# Altium schematic sheet Power Supply.SchDoc("Power Supply")
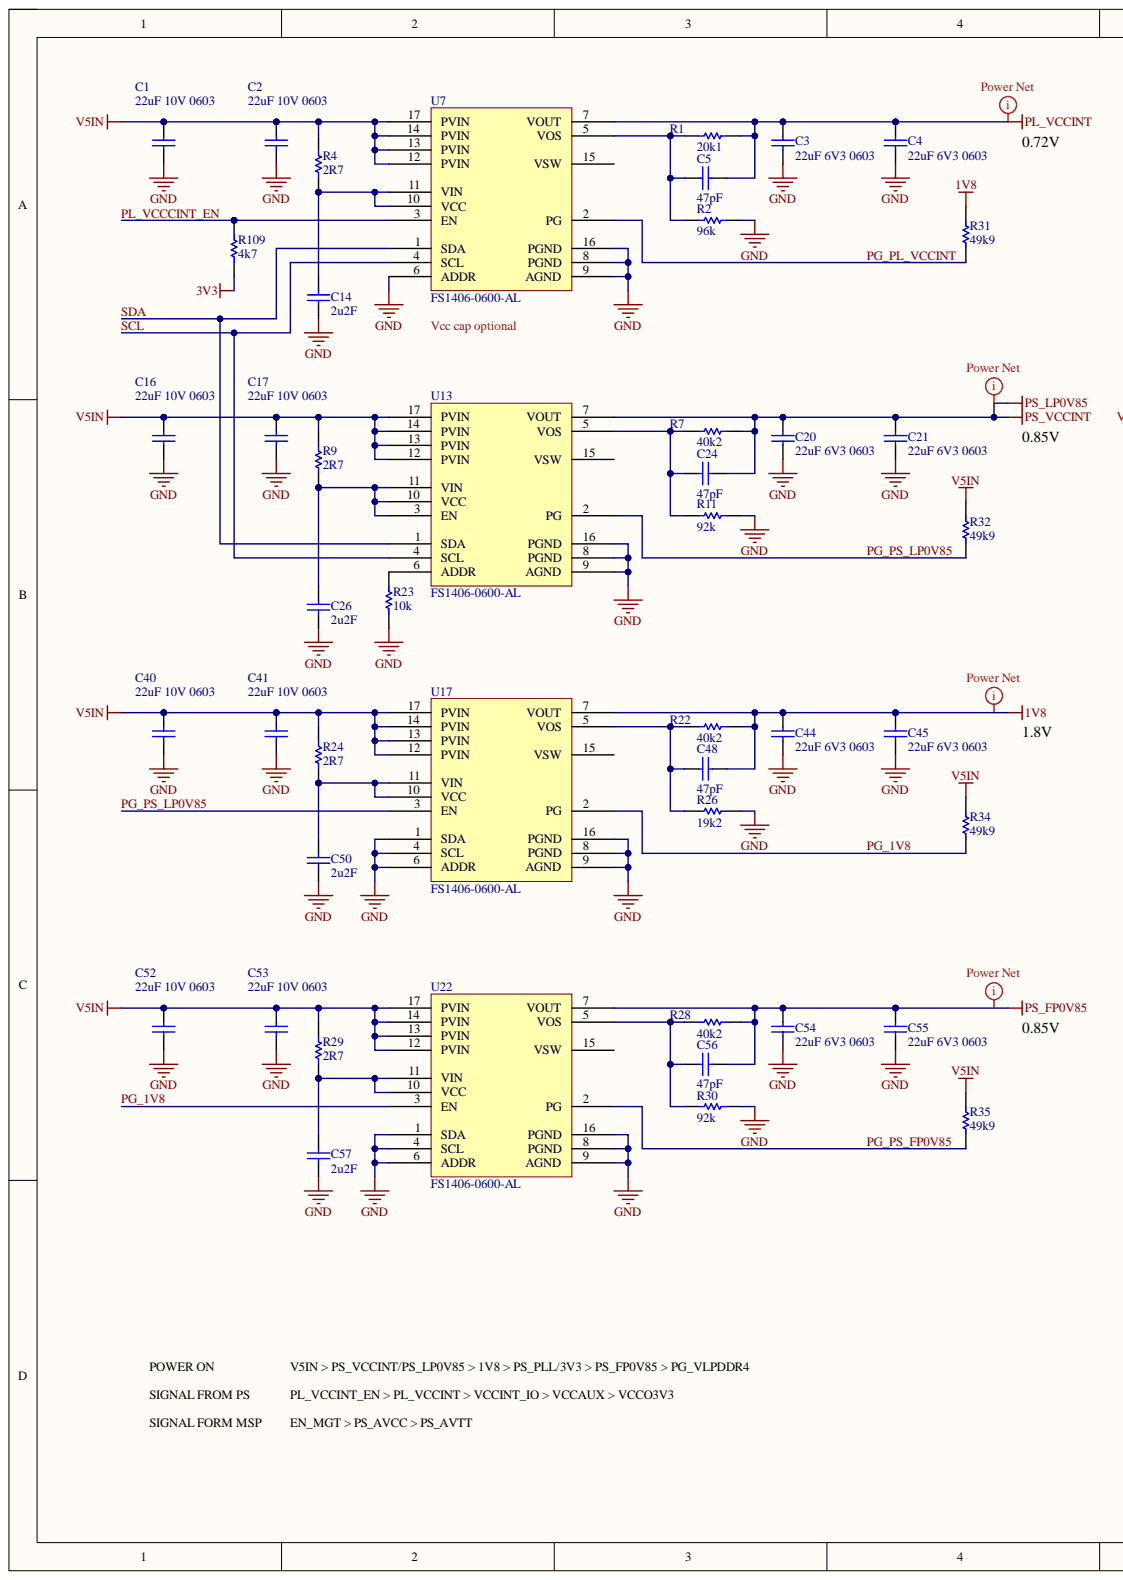
[diagram p0: Power Supply.SchDoc, left]
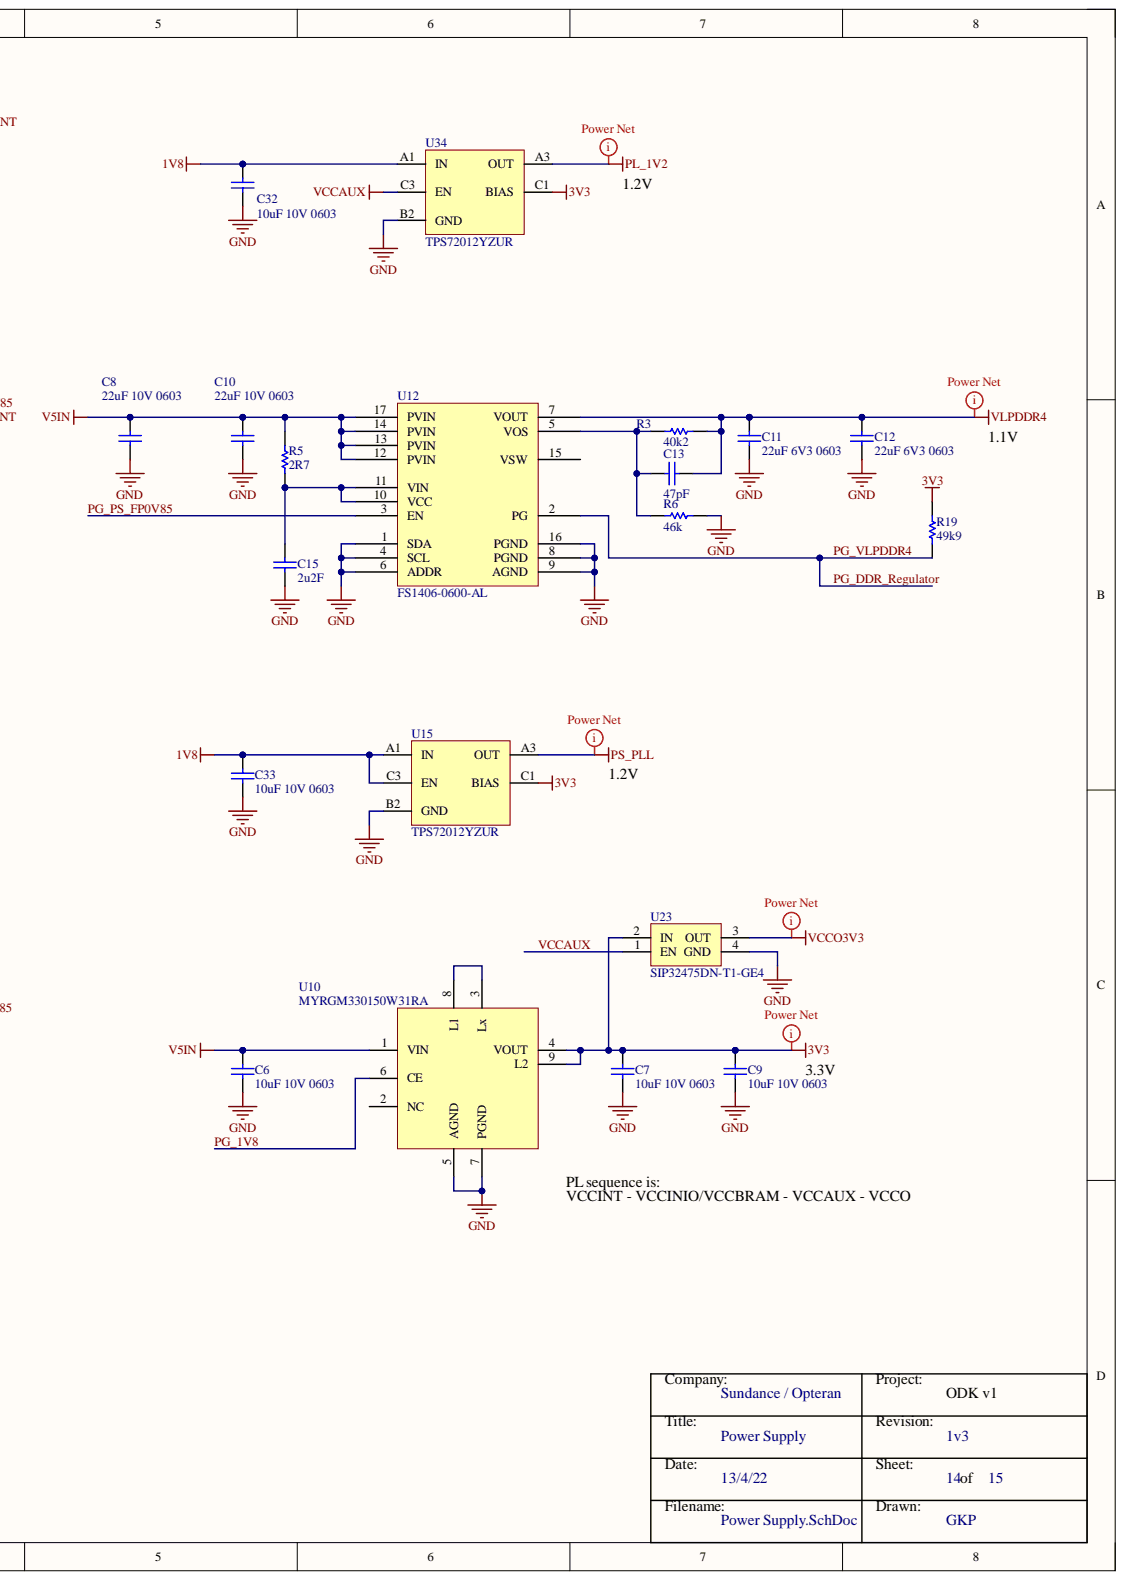
[diagram p1: Power Supply.SchDoc, right]

## Parts
C1  22uF 10V 0603  Case-EIA: 0603  [CAP0603]
C2  22uF 10V 0603  Case-EIA: 0603  [CAP0603]
C3  22uF 6V3 0603  [CAP0603]
C4  22uF 6V3 0603  Case-EIA: 0603  [CAP0603]
C5  47pF  [0201panERJ]
C6  10uF 10V 0603  [CAP0603]
C7  10uF 10V 0603  [CAP0603]
C8  22uF 10V 0603  Case-EIA: 0603  [CAP0603]
C9  10uF 10V 0603  Case-EIA: 0603  [CAP0603]
C10  22uF 10V 0603  Case-EIA: 0603  [CAP0603]
C11  22uF 6V3 0603  [CAP0603]
C12  22uF 6V3 0603  Case-EIA: 0603  [CAP0603]
C13  47pF  [0201panERJ]
C14  2u2F  [0201panERJ]
C15  2u2F  [0201panERJ]
C16  22uF 10V 0603  Case-EIA: 0603  [CAP0603]
C17  22uF 10V 0603  Case-EIA: 0603  [CAP0603]
C20  22uF 6V3 0603  [CAP0603]
C21  22uF 6V3 0603  Case-EIA: 0603  [CAP0603]
C24  47pF  [0201panERJ]
C26  2u2F  [0201panERJ]
C32  10uF 10V 0603  [CAP0603]
C33  10uF 10V 0603  [CAP0603]
C40  22uF 10V 0603  Case-EIA: 0603  [CAP0603]
C41  22uF 10V 0603  Case-EIA: 0603  [CAP0603]
C44  22uF 6V3 0603  [CAP0603]
C45  22uF 6V3 0603  Case-EIA: 0603  [CAP0603]
C48  47pF  [0201panERJ]
C50  2u2F  [0201panERJ]
C52  22uF 10V 0603  Case-EIA: 0603  [CAP0603]
C53  22uF 10V 0603  Case-EIA: 0603  [CAP0603]
C54  22uF 6V3 0603  [CAP0603]
C55  22uF 6V3 0603  Case-EIA: 0603  [CAP0603]
C56  47pF  [0201panERJ]
C57  2u2F  [0201panERJ]
R1  20k1  [RES0201]
R2  96k  [RES0201]
R3  40k2  [RES0201]
R4  2R7  [RES0201]
R5  2R7  [RES0201]
R6  46k  [RES0201]
R7  40k2  [RES0201]
R9  2R7  [RES0201]
R11  92k  [RES0201]
R19  49k9  [RES0201]
R22  40k2  [RES0201]
R23  10k  [RES0201]
R24  2R7  [RES0201]
R26  19k2  [RES0201]
R28  40k2  [RES0201]
R29  2R7  [RES0201]
R30  92k  [RES0201]
R31  49k9  [RES0201]
R32  49k9  [RES0201]
R34  49k9  [RES0201]
R35  49k9  [RES0201]
R109  4k7  [RES0201]
U7  FS1406-0600-AL  [FS1406_TDK]
U10  MYRGM330150W31RA  [Murata_MYRGM-X-MYRGM-C_MFG]
U12  FS1406-0600-AL  [FS1406_TDK]
U13  FS1406-0600-AL  [FS1406_TDK]
U15  TPS72012YZUR  [YZU0005AEBC]
U17  FS1406-0600-AL  [FS1406_TDK]
U22  FS1406-0600-AL  [FS1406_TDK]
U23  SIP32475DN-T1-GE4  [uDFN-4L 1x1mm]
U34  TPS72012YZUR  [YZU0005AEBC]

## Nets
1V8: C32.2 C33.2 C44.2 C45.2 C48.1 R22.1 R31.2 U15.A1(IN) U15.C3(EN) U17.7(VOUT) U34.A1(IN)
3V3: C7.2 C9.2 R19.2 R109.1 U10.4(VOUT) U10.9(L2) U15.C1(BIAS) U23.2(IN) U34.C1(BIAS)
GND: C1.1 C2.1 C3.1 C4.1 C6.1 C7.1 C8.1 C9.1 C10.1 C11.1 C12.1 C14.1 C15.1 C16.1 C17.1 C20.1 C21.1 C26.1 C32.1 C33.1 C40.1 C41.1 C44.1 C45.1 C50.1 C52.1 C53.1 C54.1 C55.1 C57.1 R2.1 R6.1 R11.1 R23.1 R26.1 R30.1 U7.6(ADDR) U7.8(PGND) U7.9(AGND) U7.16(PGND) U10.5(AGND) U10.7(PGND) U12.1(SDA) U12.4(SCL) U12.6(ADDR) U12.8(PGND) U12.9(AGND) U12.16(PGND) U13.8(PGND) U13.9(AGND) U13.16(PGND) U15.B2(GND) U17.1(SDA) U17.4(SCL) U17.6(ADDR) U17.8(PGND) U17.9(AGND) U17.16(PGND) U22.1(SDA) U22.4(SCL) U22.6(ADDR) U22.8(PGND) U22.9(AGND) U22.16(PGND) U23.4(GND) U34.B2(GND)
PL_1V2: U34.A3(OUT)
PL_VCCINT: C3.2 C4.2 C5.1 R1.1 U7.7(VOUT)
PS_FP0V85: C54.2 C55.2 C56.1 R28.1 U22.7(VOUT)
PS_LP0V85: C20.2 C21.2 C24.1 R7.1 U13.7(VOUT)
PS_PLL: U15.A3(OUT)
V5IN: C1.2 C2.2 C6.2 C8.2 C10.2 C16.2 C17.2 C40.2 C41.2 C52.2 C53.2 R4.2 R5.2 R9.2 R24.2 R29.2 R32.2 R34.2 R35.2 U7.12(PVIN) U7.13(PVIN) U7.14(PVIN) U7.17(PVIN) U10.1(VIN) U12.12(PVIN) U12.13(PVIN) U12.14(PVIN) U12.17(PVIN) U13.12(PVIN) U13.13(PVIN) U13.14(PVIN) U13.17(PVIN) U17.12(PVIN) U17.13(PVIN) U17.14(PVIN) U17.17(PVIN) U22.12(PVIN) U22.13(PVIN) U22.14(PVIN) U22.17(PVIN)
VCCO3V3: U23.3(OUT)
VLPDDR4: C11.2 C12.2 C13.1 R3.1 U12.7(VOUT)
PG_1V8: R34.1 U10.6(CE) U17.2(PG) U22.3(EN)
PG_DDR_Regulator: R19.1 U12.2(PG)
PG_PL_VCCINT: R31.1 U7.2(PG)
PG_PS_FP0V85: R35.1 U12.3(EN) U22.2(PG)
PG_PS_LP0V85: R32.1 U13.2(PG) U17.3(EN)
PL_VCCCINT_EN: R109.2 U7.3(EN)
SCL: U7.4(SCL) U13.4(SCL)
SDA: U7.1(SDA) U13.1(SDA)
VCCAUX: U23.1(EN) U34.C3(EN)
NetC5_2: C5.2 R1.2 R2.2 U7.5(VOS)
NetC13_2: C13.2 R3.2 R6.2 U12.5(VOS)
NetC14_2: C14.2 R4.1 U7.10(VCC) U7.11(VIN)
NetC15_2: C15.2 R5.1 U12.10(VCC) U12.11(VIN)
NetC24_2: C24.2 R7.2 R11.2 U13.5(VOS)
NetC26_2: C26.2 R9.1 U13.3(EN) U13.10(VCC) U13.11(VIN)
NetC48_2: C48.2 R22.2 R26.2 U17.5(VOS)
NetC50_2: C50.2 R24.1 U17.10(VCC) U17.11(VIN)
NetC56_2: C56.2 R28.2 R30.2 U22.5(VOS)
NetC57_2: C57.2 R29.1 U22.10(VCC) U22.11(VIN)
NetR23_2: R23.2 U13.6(ADDR)
NetU10_3: U10.3(Lx) U10.8(L1)

Not connected: U7 15(VSW); U10 2(NC); U12 15(VSW); U13 15(VSW); U17 15(VSW); U22 15(VSW)

## Library data of the parts
C1 C2 C8 C10 C16 C17 C40 C41 C52 C53 = Cap0603: 22uF 10V 0603 X5R; Component Kind: Standard; Library Name: Schematic Components.SchLib; Max Thickness: 0.6 mm; Technology: SMT
C3 C11 C20 C44 C54 = Cap0603: 22uF 6V3 0603 X5R; Case-EIA: 0603; Component Kind: Standard; Library Name: Schematic Components.SchLib; Max Thickness: 0.6 mm; Technology: SMT
C4 C12 C21 C45 C55 = Cap0603: 22uF 6V3 0603 X5R; Component Kind: Standard; Library Name: Schematic Components.SchLib; Max Thickness: 0.6 mm; Technology: SMT
C5 C13 C24 C48 C56 = Cap0201: 47pF 0201 6V3 X5R; Case-EIA: 0402; Component Kind: Standard; Library Name: Schematic Components.SchLib; Max Thickness: 0.6 mm; Technology: SMT
C6 C7 C32 C33 = Cap0603: 10uF 10V 0603 X5R; Case-EIA: 0603; Component Kind: Standard; Library Name: Schematic Components.SchLib; Max Thickness: 0.6 mm; Technology: SMT
C9 = Cap0603: 10uF 10V 0603 X5R; Component Kind: Standard; Library Name: Schematic Components.SchLib; Max Thickness: 0.6 mm; Technology: SMT
C14 C15 C26 C50 C57 = Cap0201: 2u2F 0201 10V X5R; Case-EIA: 0402; Component Kind: Standard; Library Name: Schematic Components.SchLib; Max Thickness: 0.6 mm; Technology: SMT
R1 = Res0201: 20k1 0201 1/16W 1%; Value: ?k; Component Kind: Standard; Library Name: Schematic Components.SchLib
R2 = Res0201: 96k 0201 1/16W 1%; Value: ?k; Component Kind: Standard; Library Name: Schematic Components.SchLib
R3 R7 R22 R28 = Res0201: 40k2 0201 1/16W 1%; Value: ?k; Component Kind: Standard; Library Name: Schematic Components.SchLib
R4 R5 R9 R24 R29 = Res0201: 2R7 0201 1/16W 1%; Value: ?k; Component Kind: Standard; Library Name: Schematic Components.SchLib
R6 = Res0201: 46k 0201 1/16W 1%; Value: ?k; Component Kind: Standard; Library Name: Schematic Components.SchLib
R11 R30 = Res0201: 92k 0201 1/16W 1%; Value: ?k; Component Kind: Standard; Library Name: Schematic Components.SchLib
R19 R31 R32 R34 R35 = Res0201: 49k9 0201 1/16W 1%; Value: ?k; Component Kind: Standard; Library Name: Schematic Components.SchLib
R23 = Res0201: 10k 0201 1/16W 1%; Value: ?k; Component Kind: Standard; Library Name: Schematic Components.SchLib
R26 = Res0201: 19k2 0201 1/16W 1%; Value: ?k; Component Kind: Standard; Library Name: Schematic Components.SchLib
R109 = Res0201: 4k7 0201 1/16W 1%; Value: ?k; Component Kind: Standard; Library Name: Schematic Components.SchLib
U7 U12 U13 U17 U22 = TDK FS1406: Non-Isolated PoL Module, Digital DC DC Converter 1 Output 0.6V - - - 6A 4.5V - 16V Input; Manufacturer 1: TDK Corporation; Component Kind: Standard; Library Name: Schematic Components.SchLib; Manufacturer Part Number 1: FS1406-0600-AL; Supplier 1: Digi-Key; Supplier Part Number 1: 445-FS1406-0600-ALTR-ND
U10 = MYRGM330150W31RA: Non-Isolated PoL Module DC DC Converter 1 Output 3.3V - - - 1.5A 2.7V - 5.5V Input; Manufacturer 1: Murata Power Solutions Inc.; Component Kind: Standard; Library Name: Schematic Components.SchLib; Manufacturer Part Number 1: MYRGM330150W31RA; Supplier 1: Digi-Key; Supplier Part Number 1: 811-MYRGM330150W31RATR-ND
U15 U34 = LDO TPS72012YZUR: Linear Voltage Regulator IC Positive Fixed 1 Output 350mA 5-DSBGA (0.96x1.33); Manufacturer 1: Texas Instruments; Component Kind: Standard; Library Name: Schematic Components.SchLib; Manufacturer Part Number 1: TPS72012YZUR; Supplier 1: Digi-Key; Supplier Part Number 1: 296-48774-2-ND
U23 = SiP32475: Power Switch/Driver 1:1 P-Channel 2A 4-uDFN (1x1). 1.2-5.5V; Manufacturer 1: Vishay Siliconix; Component Kind: Standard; Library Name: Schematic Components.SchLib; Manufacturer Part Number 1: SIP32475DN-T1-GE4; Supplier 1: Digi-Key; Supplier Part Number 1: SIP32475DN-T1-GE4TR-ND
